# Altium schematic sheet Buttons.SchDoc
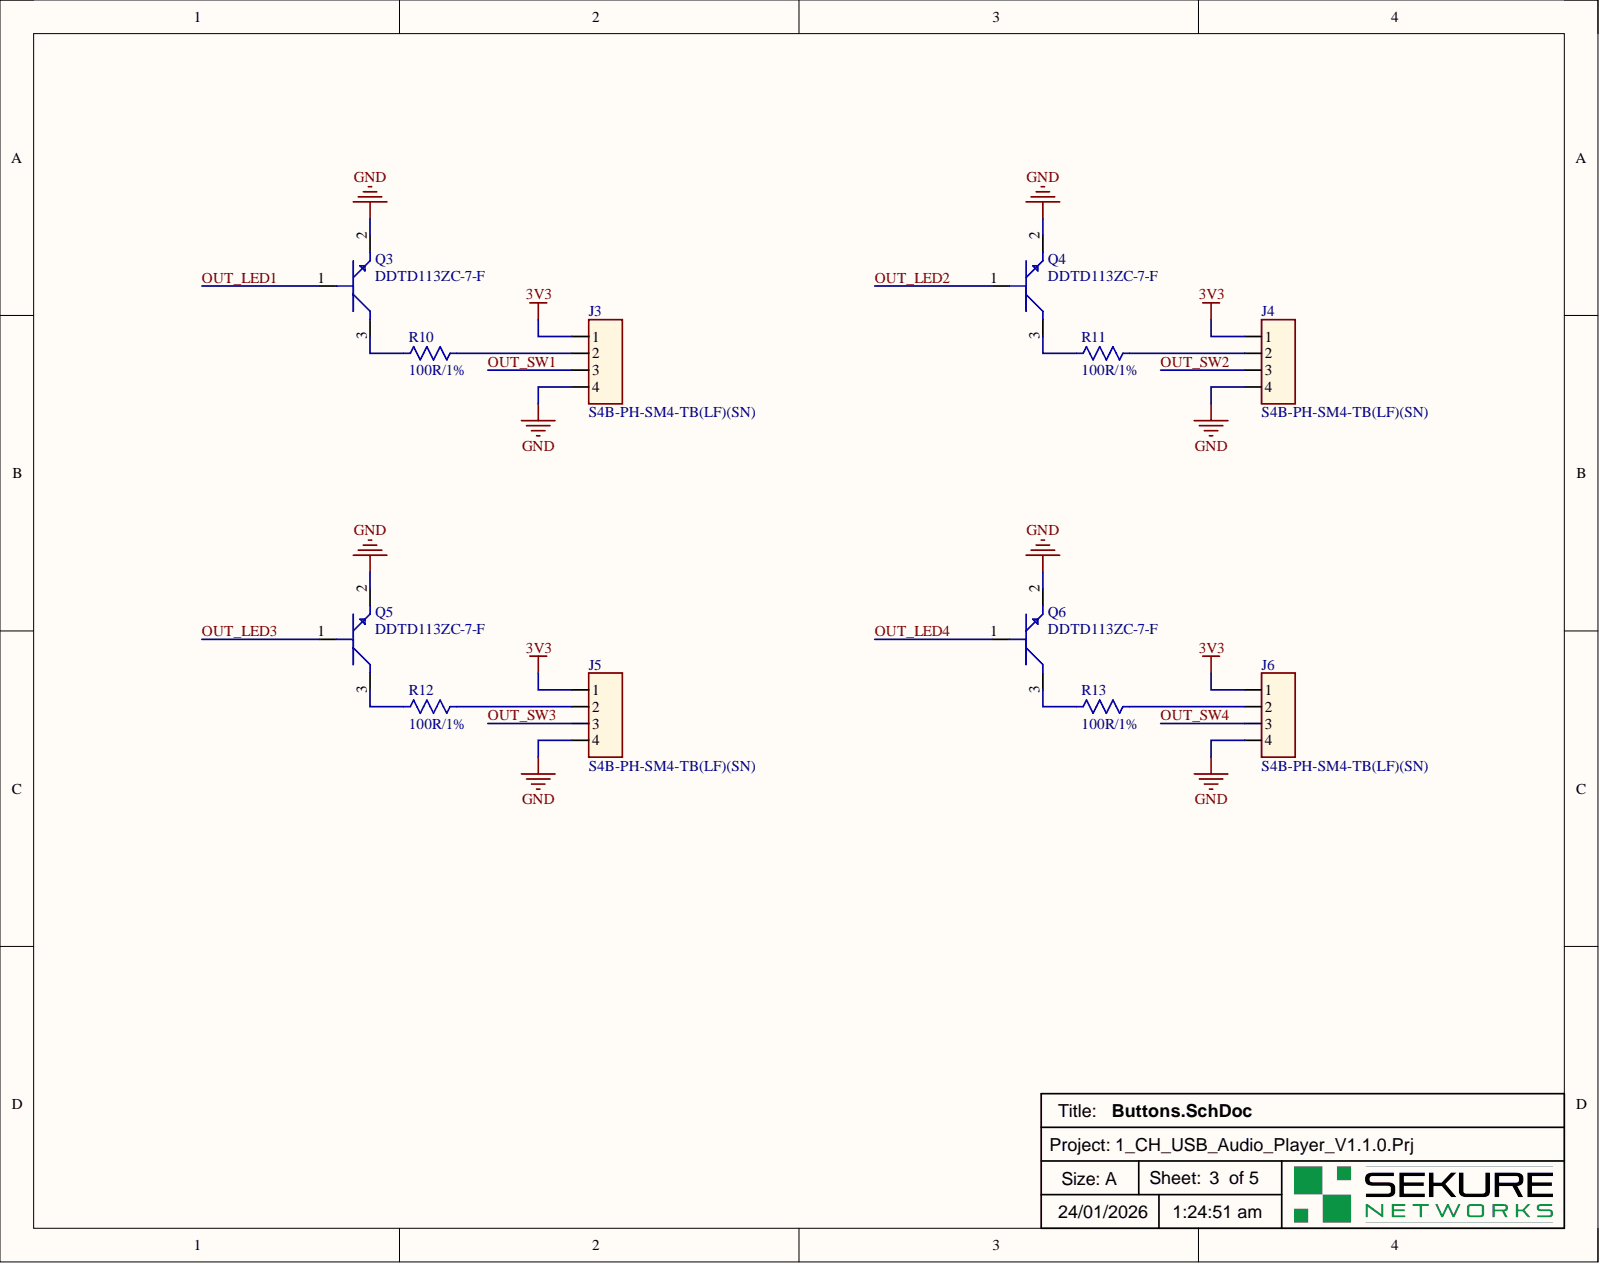
[diagram p0: Buttons.SchDoc]

## Parts
J3  value S4B-PH-SM4-TB(LF)(SN)  [S4B-PH-SM4-TB(LF)(SN)]  (hidden comment: Connector)
J4  value S4B-PH-SM4-TB(LF)(SN)  [S4B-PH-SM4-TB(LF)(SN)]  (hidden comment: Connector)
J5  value S4B-PH-SM4-TB(LF)(SN)  [S4B-PH-SM4-TB(LF)(SN)]  (hidden comment: Connector)
J6  value S4B-PH-SM4-TB(LF)(SN)  [S4B-PH-SM4-TB(LF)(SN)]  (hidden comment: Connector)
Q3  value DDTD113ZC-7-F  [SOT23]  (hidden comment: Transistor)
Q4  value DDTD113ZC-7-F  [SOT23]  (hidden comment: Transistor)
Q5  value DDTD113ZC-7-F  [SOT23]  (hidden comment: Transistor)
Q6  value DDTD113ZC-7-F  [SOT23]  (hidden comment: Transistor)
R10  value 100R/1%  [RES 0603]  (hidden comment: Resistor)
R11  value 100R/1%  [RES 0603]  (hidden comment: Resistor)
R12  value 100R/1%  [RES 0603]  (hidden comment: Resistor)
R13  value 100R/1%  [RES 0603]  (hidden comment: Resistor)

## Nets
3V3: J3.1 J4.1 J5.1 J6.1
GND: J3.4 J4.4 J5.4 J6.4 Q3.2 Q4.2 Q5.2 Q6.2
OUT_LED1: Q3.1
OUT_LED2: Q4.1
OUT_LED3: Q5.1
OUT_LED4: Q6.1
OUT_SW1: J3.3
OUT_SW2: J4.3
OUT_SW3: J5.3
OUT_SW4: J6.3
NetJ3_2: J3.2 R10.1
NetJ4_2: J4.2 R11.1
NetJ5_2: J5.2 R12.1
NetJ6_2: J6.2 R13.1
NetQ3_3: Q3.3 R10.2
NetQ4_3: Q4.3 R11.2
NetQ5_3: Q5.3 R12.2
NetQ6_3: Q6.3 R13.2

## Library data of the parts
J3-J6 = S4B-PH-SM4-TB(LF)(SN): Connector Header, PH Series, 4 Position, Side Entry, 2.00mm, Solder, Surface Mount, Natural.; Manufacturer: JST; Category: Connectors; Component Kind: Standard; ComponentLink1Description: https://datasheet.ciiva.com/11746/0900766b80df0d9d-11746552.pdf?src-supplier=RSComponents; ComponentLink1URL: https://datasheet.ciiva.com/11746/0900766b80df0d9d-11746552.pdf?src-supplier=RSComponents; ComponentLink2Description: https://datasheet.ciiva.com/26894/getdatasheetpartid-639990-26894620.pdf?src-supplier=Verical; ComponentLink2URL: https://datasheet.ciiva.com/26894/getdatasheetpartid-639990-26894620.pdf?src-supplier=Verical; ComponentLink3Description: https://datasheet.ciiva.com/16376/eph-16376783.pdf?src-supplier=Future+Electronics; ComponentLink3URL: https://datasheet.ciiva.com/16376/eph-16376783.pdf?src-supplier=Future+Electronics; ComponentLink4Description: https://datasheet.ciiva.com/8052/1699381-8052234.pdf?src-supplier=Element14; ComponentLink4URL: https://datasheet.ciiva.com/8052/1699381-8052234.pdf?src-supplier=Element14; ComponentLink5Description: https://datasheet.ciiva.com/712/eph-712578.pdf?src-supplier=Digi-Key; ComponentLink5URL: https://datasheet.ciiva.com/712/eph-712578.pdf?src-supplier=Digi-Key; ComponentLink6Description: https://datasheet.ciiva.com/712/eph-712579.pdf?src-supplier=Digi-Key; ComponentLink6URL: https://datasheet.ciiva.com/712/eph-712579.pdf?src-supplier=Digi-Key; ComponentLink7Description: https://datasheet.ciiva.com/730/eph-730675.pdf?src-supplier=Digi-Key; ComponentLink7URL: https://datasheet.ciiva.com/730/eph-730675.pdf?src-supplier=Digi-Key; DigiKey: 455-1751-1-ND; Library Name: Connectors.IntLib; P/N: S4B-PH-SM4-TB(LF)(SN); Pricing 1: 1=0.74000, 10=0.64300, 100=0.53020, 500=0.46108 (USD); RoHS: Yes; Stock 1: 33878; Supplier 1: Digi-Key
Q3-Q6 = DDTD113ZC-7-F: DDTDxxxxC Series NPN 50 V 500 mA 1 kO/10 kO Pre-Baised Transistor - SOT-23; Manufacturer: Diodes Zetex; Category: BJTs; Component Kind: Standard; ComponentLink1Description: https://datasheet.ciiva.com/15139/getdatasheetpartid-323116-15139824.pdf?src-supplier=Verical; ComponentLink1URL: https://datasheet.ciiva.com/15139/getdatasheetpartid-323116-15139824.pdf?src-supplier=Verical; DigiKey: DDTD113ZC-7FDICT-ND; Library Name: Transistor.IntLib; P/N: DDTD113ZC-7-F; Pricing: 1=0.17, 10=0.117, 100=0.0784, 500=0.05978, 1000=0.0535 (USD); RoHS: Yes; Stock: 756266; Supplier: Digikey
R10-R13 = ERJ-3EKF1000V: Res Thick Film 0603 100 Ohm 1% 1/10W ±100ppm/°C Molded SMD Punched Carrier T/R; Manufacturer: Panasonic; Max Power Dissipation: 100mW; Voltage Rating: 75V; Case Code (Imperial): 0603; Case/Package: 0603; Category: Chip SMD Resistors; China RoHS: Non-Compliant; Component Kind: Standard; ComponentLink1Description: https://datasheet.octopart.com:443/ERJ-3EKF1000V-Panasonic-datasheet-82288794.pdf?src-supplier=Future+Electronics; ComponentLink1URL: https://datasheet.octopart.com:443/ERJ-3EKF1000V-Panasonic-datasheet-82288794.pdf?src-supplier=Future+Electronics; ComponentLink2Description: https://datasheet.octopart.com:443/ERJ-3EKF1000V-Panasonic-datasheet-10025800.pdf?src-supplier=Future+Electronics; ComponentLink2URL: https://datasheet.octopart.com:443/ERJ-3EKF1000V-Panasonic-datasheet-10025800.pdf?src-supplier=Future+Electronics; ComponentLink3Description: https://datasheet.octopart.com:443/ERJ-3EKF1000V-Panasonic-datasheet-8853621.pdf?src-supplier=Future+Electronics; ComponentLink3URL: https://datasheet.octopart.com:443/ERJ-3EKF1000V-Panasonic-datasheet-8853621.pdf?src-supplier=Future+Electronics; ComponentLink4Description: https://datasheet.octopart.com:443/ERJ-3EKF1000V-Panasonic-datasheet-5313456.pdf?src-supplier=Future+Electronics; ComponentLink4URL: https://datasheet.octopart.com:443/ERJ-3EKF1000V-Panasonic-datasheet-5313456.pdf?src-supplier=Future+Electronics; ComponentLink5Description: https://datasheet.octopart.com:443/ERJ-3EKF1000V-Panasonic-datasheet-13266541.pdf?src-supplier=RS+(Formerly+Allied+Electronics); ComponentLink5URL: https://datasheet.octopart.com:443/ERJ-3EKF1000V-Panasonic-datasheet-13266541.pdf?src-supplier=RS+(Formerly+Allied+Electronics); ComponentLink6Description: https://datasheet.octopart.com:443/ERJ-3EKF1000V-Panasonic-datasheet-48891056.pdf?src-supplier=Newark; ComponentLink6URL: https://datasheet.octopart.com:443/ERJ-3EKF1000V-Panasonic-datasheet-48891056.pdf?src-supplier=Newark; ComponentLink7Description: https://datasheet.octopart.com:443/ERJ-3EKF1000V-Panasonic-datasheet-168681906.pdf?src-supplier=Newark; ComponentLink7URL: https://datasheet.octopart.com:443/ERJ-3EKF1000V-Panasonic-datasheet-168681906.pdf?src-supplier=Newark; ComponentLink8Description: https://datasheet.octopart.com:443/ERJ-3EKF1000V-Panasonic-datasheet-136608610.pdf?src-supplier=Farnell; ComponentLink8URL: https://datasheet.octopart.com:443/ERJ-3EKF1000V-Panasonic-datasheet-136608610.pdf?src-supplier=Farnell; ComponentLink9Description: https://datasheet.octopart.com:443/ERJ-3EKF1000V-Panasonic-datasheet-154766169.pdf?src-supplier=Master+Electronics; ComponentLink9URL: https://datasheet.octopart.com:443/ERJ-3EKF1000V-Panasonic-datasheet-154766169.pdf?src-supplier=Master+Electronics; ComponentLink10Description: https://datasheet.octopart.com:443/ERJ3EKF1000V-Panasonic-datasheet-138819429.pdf?src-supplier=Burklin+Elektronik; ComponentLink10URL: https://datasheet.octopart.com:443/ERJ3EKF1000V-Panasonic-datasheet-138819429.pdf?src-supplier=Burklin+Elektronik; ComponentLink11Description: https://datasheet.octopart.com/ERJ-3EKF1000V-Panasonic-datasheet-78375410.pdf; ComponentLink11URL: https://datasheet.octopart.com/ERJ-3EKF1000V-Panasonic-datasheet-78375410.pdf; ComponentLink12Description: https://datasheet.octopart.com/Precision-Thick-Film-Chip-Resistors-Panasonic-datasheet-family-106.pdf; ComponentLink12URL: https://datasheet.octopart.com/Precision-Thick-Film-Chip-Resistors-Panasonic-datasheet-family-106.pdf; ComponentLink13Description: https://datasheet.octopart.com:443/ERJ-3EKF1000V-Panasonic-datasheet-7538305.pdf?src-supplier=Jameco; ComponentLink13URL: https://datasheet.octopart.com:443/ERJ-3EKF1000V-Panasonic-datasheet-7538305.pdf?src-supplier=Jameco; ComponentLink14Description: https://datasheet.octopart.com:443/ERJ-3EKF1000V-Panasonic-datasheet-118621.pdf?src-supplier=DigiKey; ComponentLink14URL: https://datasheet.octopart.com:443/ERJ-3EKF1000V-Panasonic-datasheet-118621.pdf?src-supplier=DigiKey; ComponentLink15Description: https://datasheet.octopart.com:443/ERJ-3EKF1000V-Panasonic-datasheet-41391857.pdf?src-supplier=Electro+Sonic; ComponentLink15URL: https://datasheet.octopart.com:443/ERJ-3EKF1000V-Panasonic-datasheet-41391857.pdf?src-supplier=Electro+Sonic; Composition: Thick Film; Contact Plating: Tin; Depth: 800µm; DigiKey: P100HCT-ND; Features: Automotive AEC-Q200; Height: 450µm; Lead Free: Lead Free; Length: 1.6mm; Library Name: Resistors.IntLib; Lifecycle Status: Production; Max Operating Temperature: 155°C; Military Standard: Not; Min Operating Temperature: -55°C; Mounting Technology: Surface Mount; Number of Terminals: 2; Number of Terminations: 2; P/N: ERJ-3EKF1000V; Packaging: Cut Tape; Pins: 2; Power Dissipation: 100mW; Power Rating: 100mW; Pricing: 1=0.1, 10=0.034, 25=0.0256, 50=0.021, 100=0.0173, 250=0.01364, 500=0.01158, 1000=0.00995 (USD); Radiation Hardening: No; REACH SVHC: Yes; RoHS: Compliant; Schedule B: 8533210045, 8533210045|8533210045|8533210045|8533210045|8533210045|8533210045, 8533210045|8533210045|8533210045|8533210045|8533210045|8533210045|8533210045|8533210045|8533210045|8533210045|8533210045|8533210045|8533210045|8533210045|8533210045|8533210045|8533210045|8533210045|8533210045|8533210045|8533210045|8533210045|8533210045|85; Stock: 314717; Supplier: DigiKey; Temperature Coefficient: 100ppm/°C; Termination: SMD/SMT; Tolerance: 1%; Voltage Rating (DC): 75V; Weight: 2.012816mg; Width: 800µm; Working Voltage: 75V
